# Altium schematic sheet Power.SchDoc(Power) (continued)
## Library data of the parts (continued)
FB1 = FBMH3225HM601NT: Ferrite Beads Power Chip 600Ohm 30% 100MHz 3A 0.042Ohm DCR 1210 T/R; Manufacturer: TAIYO YUDEN; Manufacturer Package Identifier: 1210 (3225 Metric); DC Resistance (DCR): 42mΩ; Impedance: 600Ω; Number of Circuits: 1; Shielding: Unshielded; Value: 42mΩ; Case Code (Imperial): 1210; Case Code (Metric): 3225; Case/Package: 1210; Category: Ferrite Beads and Chips; Clock Frequency: 100MHz; Component Kind: Standard; ComponentLink1Description: https://datasheet.octopart.com:443/FBMH3225HM601NT-Taiyo-Yuden-datasheet-151884371.pdf?src-supplier=IHS; ComponentLink1URL: https://datasheet.octopart.com:443/FBMH3225HM601NT-Taiyo-Yuden-datasheet-151884371.pdf?src-supplier=IHS; ComponentLink2Description: https://datasheet.octopart.com:443/FBMH3225HM601NT-Taiyo-Yuden-datasheet-154808381.pdf?src-supplier=Master+Electronics; ComponentLink2URL: https://datasheet.octopart.com:443/FBMH3225HM601NT-Taiyo-Yuden-datasheet-154808381.pdf?src-supplier=Master+Electronics; ComponentLink3Description: https://datasheet.octopart.com:443/FBMH3225HM601NT-Taiyo-Yuden-datasheet-41181550.pdf?src-supplier=Newark; ComponentLink3URL: https://datasheet.octopart.com:443/FBMH3225HM601NT-Taiyo-Yuden-datasheet-41181550.pdf?src-supplier=Newark; ComponentLink4Description: https://datasheet.octopart.com:443/FBMH3225HM601NT-Taiyo-Yuden-datasheet-27088936.pdf?src-supplier=Newark; ComponentLink4URL: https://datasheet.octopart.com:443/FBMH3225HM601NT-Taiyo-Yuden-datasheet-27088936.pdf?src-supplier=Newark; ComponentLink5Description: https://datasheet.octopart.com:443/FBMH3225HM601NT-Taiyo-Yuden-datasheet-17272606.pdf?src-supplier=Newark; ComponentLink5URL: https://datasheet.octopart.com:443/FBMH3225HM601NT-Taiyo-Yuden-datasheet-17272606.pdf?src-supplier=Newark; ComponentLink6Description: https://datasheet.octopart.com:443/FBMH3225HM601NT-Taiyo-Yuden-datasheet-101598981.pdf?src-supplier=Newark; ComponentLink6URL: https://datasheet.octopart.com:443/FBMH3225HM601NT-Taiyo-Yuden-datasheet-101598981.pdf?src-supplier=Newark; ComponentLink7Description: https://datasheet.octopart.com:443/FBMH3225HM601NT-Taiyo-Yuden-datasheet-10545600.pdf?src-supplier=Factory+Futures; ComponentLink7URL: https://datasheet.octopart.com:443/FBMH3225HM601NT-Taiyo-Yuden-datasheet-10545600.pdf?src-supplier=Factory+Futures; ComponentLink8Description: https://datasheet.octopart.com:443/FBMH3225HM601NT-Taiyo-Yuden-datasheet-119523.pdf?src-supplier=Farnell; ComponentLink8URL: https://datasheet.octopart.com:443/FBMH3225HM601NT-Taiyo-Yuden-datasheet-119523.pdf?src-supplier=Farnell; ComponentLink9Description: https://datasheet.octopart.com:443/FBMH3225HM601NT-Taiyo-Yuden-datasheet-10742123.pdf?src-supplier=Farnell; ComponentLink9URL: https://datasheet.octopart.com:443/FBMH3225HM601NT-Taiyo-Yuden-datasheet-10742123.pdf?src-supplier=Farnell; ComponentLink10Description: https://datasheet.octopart.com:443/FBMH3225HM601NT-Taiyo-Yuden-datasheet-10622963.pdf?src-supplier=Farnell; ComponentLink10URL: https://datasheet.octopart.com:443/FBMH3225HM601NT-Taiyo-Yuden-datasheet-10622963.pdf?src-supplier=Farnell; ComponentLink11Description: https://datasheet.octopart.com:443/FBMH3225HM601NT-Taiyo-Yuden-datasheet-10618026.pdf?src-supplier=Farnell; ComponentLink11URL: https://datasheet.octopart.com:443/FBMH3225HM601NT-Taiyo-Yuden-datasheet-10618026.pdf?src-supplier=Farnell; ComponentLink12Description: https://datasheet.octopart.com:443/FBMH3225HM601NT-Taiyo-Yuden-datasheet-10549048.pdf?src-supplier=Farnell; ComponentLink12URL: https://datasheet.octopart.com:443/FBMH3225HM601NT-Taiyo-Yuden-datasheet-10549048.pdf?src-supplier=Farnell; ComponentLink13Description: https://datasheet.octopart.com:443/FBMH3225HM601NT-Taiyo-Yuden-datasheet-10671320.pdf?src-supplier=Farnell; ComponentLink13URL: https://datasheet.octopart.com:443/FBMH3225HM601NT-Taiyo-Yuden-datasheet-10671320.pdf?src-supplier=Farnell; ComponentLink14Description: https://datasheet.octopart.com:443/FBMH3225HM601NT-Taiyo-Yuden-datasheet-10620942.pdf?src-supplier=Farnell; ComponentLink14URL: https://datasheet.octopart.com:443/FBMH3225HM601NT-Taiyo-Yuden-datasheet-10620942.pdf?src-supplier=Farnell; ComponentLink15Description: https://datasheet.octopart.com:443/FBMH3225HM601NT-Taiyo-Yuden-datasheet-10408854.pdf?src-supplier=Farnell; ComponentLink15URL: https://datasheet.octopart.com:443/FBMH3225HM601NT-Taiyo-Yuden-datasheet-10408854.pdf?src-supplier=Farnell; ComponentLink16Description: https://datasheet.octopart.com:443/FBMH3225HM601NT-Taiyo-Yuden-datasheet-10283011.pdf?src-supplier=Farnell; ComponentLink16URL: https://datasheet.octopart.com:443/FBMH3225HM601NT-Taiyo-Yuden-datasheet-10283011.pdf?src-supplier=Farnell; ComponentLink17Description: https://datasheet.octopart.com:443/FBMH3225HM601NT-Taiyo-Yuden-datasheet-10031713.pdf?src-supplier=Farnell; ComponentLink17URL: https://datasheet.octopart.com:443/FBMH3225HM601NT-Taiyo-Yuden-datasheet-10031713.pdf?src-supplier=Farnell; ComponentLink18Description: https://datasheet.octopart.com:443/FBMH3225HM601NT-Taiyo-Yuden-datasheet-8857305.pdf?src-supplier=Farnell; ComponentLink18URL: https://datasheet.octopart.com:443/FBMH3225HM601NT-Taiyo-Yuden-datasheet-8857305.pdf?src-supplier=Farnell; ComponentLink19Description: https://datasheet.octopart.com:443/FBMH3225HM601NT-Taiyo-Yuden-datasheet-10854574.pdf?src-supplier=Farnell; ComponentLink19URL: https://datasheet.octopart.com:443/FBMH3225HM601NT-Taiyo-Yuden-datasheet-10854574.pdf?src-supplier=Farnell; ComponentLink20Description: https://datasheet.octopart.com:443/FBMH3225HM601NT-Taiyo-Yuden-datasheet-8820216.pdf?src-supplier=Farnell; ComponentLink20URL: https://datasheet.octopart.com:443/FBMH3225HM601NT-Taiyo-Yuden-datasheet-8820216.pdf?src-supplier=Farnell; ComponentLink21Description: https://datasheet.octopart.com:443/FBMH3225HM601NT-Taiyo-Yuden-datasheet-120265.pdf?src-supplier=Farnell; ComponentLink21URL: https://datasheet.octopart.com:443/FBMH3225HM601NT-Taiyo-Yuden-datasheet-120265.pdf?src-supplier=Farnell; ComponentLink22Description: https://datasheet.octopart.com:443/FBMH3225HM601NT-Taiyo-Yuden-datasheet-5326109.pdf?src-supplier=Farnell; ComponentLink22URL: https://datasheet.octopart.com:443/FBMH3225HM601NT-Taiyo-Yuden-datasheet-5326109.pdf?src-supplier=Farnell; ComponentLink23Description: https://datasheet.octopart.com:443/FBMH3225HM601NT-Taiyo-Yuden-datasheet-118905.pdf?src-supplier=Farnell; ComponentLink23URL: https://datasheet.octopart.com:443/FBMH3225HM601NT-Taiyo-Yuden-datasheet-118905.pdf?src-supplier=Farnell; ComponentLink24Description: https://datasheet.octopart.com:443/FBMH3225HM601NT-Taiyo-Yuden-datasheet-1645.pdf?src-supplier=Farnell; ComponentLink24URL: https://datasheet.octopart.com:443/FBMH3225HM601NT-Taiyo-Yuden-datasheet-1645.pdf?src-supplier=Farnell; ComponentLink25Description: https://datasheet.octopart.com:443/FBMH3225HM601NT-Taiyo-Yuden-datasheet-101669861.pdf?src-supplier=Future+Electronics; ComponentLink25URL: https://datasheet.octopart.com:443/FBMH3225HM601NT-Taiyo-Yuden-datasheet-101669861.pdf?src-supplier=Future+Electronics; ComponentLink26Description: https://datasheet.octopart.com:443/FBMH3225HM601NT-Taiyo-Yuden-datasheet-99833420.pdf?src-supplier=Future+Electronics; ComponentLink26URL: https://datasheet.octopart.com:443/FBMH3225HM601NT-Taiyo-Yuden-datasheet-99833420.pdf?src-supplier=Future+Electronics; ComponentLink27Description: https://datasheet.octopart.com:443/FBMH3225HM601NT-Taiyo-Yuden-datasheet-62109277.pdf?src-supplier=Electro+Sonic; ComponentLink27URL: https://datasheet.octopart.com:443/FBMH3225HM601NT-Taiyo-Yuden-datasheet-62109277.pdf?src-supplier=Electro+Sonic; ComponentLink28Description: https://datasheet.octopart.com:443/FBMH3225HM601NT-Taiyo-Yuden-datasheet-6398493.pdf?src-supplier=element14; ComponentLink28URL: https://datasheet.octopart.com:443/FBMH3225HM601NT-Taiyo-Yuden-datasheet-6398493.pdf?src-supplier=element14; Core Material: Ferrite; Current Rating: 3A; Depth: 2.8mm; ESR: 42mΩ; Export Control Classification Number (ECCN) Code: EAR99; Frequency: 100MHz; Halogen Free: Halogen Free; Harmonized Tariff Schedule (HTS) Code: 8504.50.80.00; Height: 2.5mm; Introduction Date: 2002-01-01; Lead Free: Lead Free; Length: 3.2mm; Lifecycle Status: Production; LTB Date: 2026-03-31; LTD Date: 2026-03-31; Manufacturer Part Number: FBMH3225HM601NT; Material: Ferrite; Max Current Rating: 3A; Max DC Current: 3A; Max Length: 3.5mm; Max Operating Temperature: 85°C; Max Thickness: 2.8mm; Max Width: 2.8mm; Min Length: 2.9mm; Min Operating Temperature: -40°C; Min Thickness: 2.2mm; Min Width: 2.2mm; Mounting Technology: Surface Mount; Number of Lines: 1; Number of Terminals: 2; Packaging: Digi-Reel®; Pins: 2; Pricing: 1=0.53, 10=0.371, 25=0.3204, 50=0.2856, 100=0.2537, 250=0.21508, 500=0.18832 (USD); REACH SVHC: Unaffected; RMS Current (Irms): 3A; RoHS: Compliant; Stock: 198378; Supplier: DigiKey; Supplier Part Number: 587-1755-1-ND; Termination: SMD/SMT; Test Frequency: 100MHz; Tolerance: 30%; Width: 2.5mm; Library Name: Tremelo_Pedal.SchLib
J1 = 54-00129: Conn DC Power RCP 2 POS Solder RA Thru-Hole 3 Terminal 1 Port; Manufacturer: Tensility; Current Rating: 6A; Voltage Rating: 48V; Category: Connectors; Component Kind: Standard; ComponentLink1Description: https://datasheet.octopart.com:443/54-00129-Tensility-datasheet-189118334.pdf?src-supplier=Master+Electronics; ComponentLink1URL: https://datasheet.octopart.com:443/54-00129-Tensility-datasheet-189118334.pdf?src-supplier=Master+Electronics; Contact Material: Brass; Export Control Classification Number (ECCN) Code: EAR99; Harmonized Tariff Schedule (HTS) Code: 8536.69.40.20; Manufacturer Part Number: 54-00129; Max Operating Temperature: 85°C; Min Operating Temperature: -25°C; Pricing: 1=0.88, 10=0.745, 25=0.6976, 50=0.6644, 100=0.6326, 250=0.59296, 500=0.56462, 1000=0.53765, 2500=0.50399 (USD); REACH SVHC: Affected; RoHS: Compliant; Schedule B: 8536695050, 8536695050|8536695050|8536695050|8536695050|8536695050; Stock: 18126; Supplier: DigiKey; Supplier Part Number: 839-54-00129-ND; Termination: Solder; Library Name: Tremelo_Pedal.SchLib
Q1 = MMBT2222ALT1G: Bipolar (BJT) Transistor NPN 40V 600mA 300MHz 225mW Surface Mount SOT-23-3 Tape & Reel; Manufacturer: onsemi; Manufacturer Lifecycle Status: ACTIVE; Collector Base Voltage (VCBO): 75V; Collector Emitter Saturation Voltage: 300mV; Element Configuration: Single; Emitter Base Voltage (VEBO): 6V; hFE Min: 75; Max Junction Temperature (Tj): 150°C; Max Power Dissipation: 300mW; Number of Elements: 1; Type: General Purpose; Case/Package: SOT-23; Category: BJTs; China RoHS: Compliant; Clock Frequency: 300MHz; Collector Emitter Breakdown Voltage: 40V; Collector Emitter Voltage (VCEO): 40V; Component Kind: Standard; ComponentLink1Description: https://datasheet.octopart.com:443/MMBT2222ALT1G-ON-Semiconductor-datasheet-610557.pdf?src-supplier=onsemi; ComponentLink1URL: https://datasheet.octopart.com:443/MMBT2222ALT1G-ON-Semiconductor-datasheet-610557.pdf?src-supplier=onsemi; ComponentLink2Description: https://datasheet.octopart.com:443/MMBT2222ALT1G-ON-Semiconductor-datasheet-8326918.pdf?src-supplier=onsemi; ComponentLink2URL: https://datasheet.octopart.com:443/MMBT2222ALT1G-ON-Semiconductor-datasheet-8326918.pdf?src-supplier=onsemi; ComponentLink3Description: https://datasheet.octopart.com:443/MMBT2222ALT1G-onsemi-datasheet-180963556.pdf?src-supplier=IHS; ComponentLink3URL: https://datasheet.octopart.com:443/MMBT2222ALT1G-onsemi-datasheet-180963556.pdf?src-supplier=IHS; ComponentLink4Description: https://datasheet.octopart.com:443/MMBT2222ALT1G-ON-Semiconductor-datasheet-93401943.pdf?src-supplier=Upverter; ComponentLink4URL: https://datasheet.octopart.com:443/MMBT2222ALT1G-ON-Semiconductor-datasheet-93401943.pdf?src-supplier=Upverter; ComponentLink5Description: https://sigma.octopart.com:443/138897241/technical_drawing/ON-Semiconductor-MMBT2222ALT1G.pdf?src-supplier=Upverter; ComponentLink5URL: https://sigma.octopart.com:443/138897241/technical_drawing/ON-Semiconductor-MMBT2222ALT1G.pdf?src-supplier=Upverter; ComponentLink6Description: https://datasheet.octopart.com:443/MMBT2222ALT1G-ON-Semiconductor-datasheet-11598741.pdf?src-supplier=Farnell; ComponentLink6URL: https://datasheet.octopart.com:443/MMBT2222ALT1G-ON-Semiconductor-datasheet-11598741.pdf?src-supplier=Farnell; ComponentLink7Description: https://datasheet.octopart.com:443/MMBT2222ALT1G-ON-Semiconductor-datasheet-155100582.pdf?src-supplier=TME; ComponentLink7URL: https://datasheet.octopart.com:443/MMBT2222ALT1G-ON-Semiconductor-datasheet-155100582.pdf?src-supplier=TME; ComponentLink8Description: https://datasheet.octopart.com:443/MMBT2222ALT1G-ON-Semiconductor-datasheet-9666439.pdf?src-supplier=RS+(Formerly+Allied+Electronics); ComponentLink8URL: https://datasheet.octopart.com:443/MMBT2222ALT1G-ON-Semiconductor-datasheet-9666439.pdf?src-supplier=RS+(Formerly+Allied+Electronics); ComponentLink9Description: http://sigma.octopart.com:80/150618391/rohs_statement/ON-Semiconductor-MMBT2222ALT1G.pdf?src-supplier=Compliance+Statements; ComponentLink9URL: http://sigma.octopart.com:80/150618391/rohs_statement/ON-Semiconductor-MMBT2222ALT1G.pdf?src-supplier=Compliance+Statements; ComponentLink10Description: http://sigma.octopart.com:80/reach_statement/ON-Semiconductor-company-79.pdf?src-supplier=Compliance+Statements; ComponentLink10URL: http://sigma.octopart.com:80/reach_statement/ON-Semiconductor-company-79.pdf?src-supplier=Compliance+Statements; ComponentLink11Description: http://sigma.octopart.com:80/rohs_statement/Fairchild-Semiconductor-company-11.pdf?src-supplier=Compliance+Statements; ComponentLink11URL: http://sigma.octopart.com:80/rohs_statement/Fairchild-Semiconductor-company-11.pdf?src-supplier=Compliance+Statements; ComponentLink12Description: http://sigma.octopart.com:80/rohs_statement/Fairchild-Semiconductor-company-10.pdf?src-supplier=Compliance+Statements; ComponentLink12URL: http://sigma.octopart.com:80/rohs_statement/Fairchild-Semiconductor-company-10.pdf?src-supplier=Compliance+Statements; ComponentLink13Description: http://sigma.octopart.com:80/reach_statement/Fairchild-Semiconductor-company-9.pdf?src-supplier=Compliance+Statements; ComponentLink13URL: http://sigma.octopart.com:80/reach_statement/Fairchild-Semiconductor-company-9.pdf?src-supplier=Compliance+Statements; ComponentLink14Description: https://octopart.com:443/opatz8j6/c1?t=qUfD7E2yMa3Yk1vLXuv8OyRrgB35oCXT9-4lZ8hWbx63qVKY6CZ5Wx2VuO9N1oPnQ-OFoqvKrlbsAQTZvNQspaV8PzM0KMZrM5qimrAS2Zvqv1Jmjhq1XNHbtRnsNKP4QJNWwAWuXHzspahX0GPiJWYED5gCsLAWVM_Us9JHtNxAPP0IoypvAlSchXMw0lNpAwxWQgbKGZRbXI3egbgLrDMNwqXcjYqK5Lts70q_IXAZE63Sk2NOAQAaUe72vfA1BkFyWuN3Pafh36sNqvi0mkmDOT1QicIxslPPZmZplA9bng580C9Wj3PNCWJxQeY76huV7G-1Ts4qzJ3fZbIoJFL71Wq9dv0nuozXNA_jig8&src-supplier=Compliance+Statements; ComponentLink14URL: https://octopart.com:443/opatz8j6/c1?t=qUfD7E2yMa3Yk1vLXuv8OyRrgB35oCXT9-4lZ8hWbx63qVKY6CZ5Wx2VuO9N1oPnQ-OFoqvKrlbsAQTZvNQspaV8PzM0KMZrM5qimrAS2Zvqv1Jmjhq1XNHbtRnsNKP4QJNWwAWuXHzspahX0GPiJWYED5gCsLAWVM_Us9JHtNxAPP0IoypvAlSchXMw0lNpAwxWQgbKGZRbXI3egbgLrDMNwqXcjYqK5Lts70q_IXAZE63Sk2NOAQAaUe72vfA1BkFyWuN3Pafh36sNqvi0mkmDOT1QicIxslPPZmZplA9bng580C9Wj3PNCWJxQeY76huV7G-1Ts4qzJ3fZbIoJFL71Wq9dv0nuozXNA_jig8&src-supplier=Compliance+Statements; ComponentLink15Description: https://octopart.com:443/opatz8j6/c1?t=kIt2DkC0MAyf1TCB62HN4xrKGgEtOl4LXqimdH22cZ_WyAC3wC3E4Hdpe_0KznFcpnPTUsRM23Gl7aUqdnmdck66MDx_v__wJydKmihBqdbws59vCRNJfil0wkQBEwEF0_4QxDPd5IBZVfNlTKO6LnTTXAfkmbX-sPSl7uFpYsmiM-Sa7nH5TCfNiTPGpSB6kRuHhRRQlxAWjs174o_s8ub9WNbbjmqKG85Y2S3lbzJ_5XqEIWEtZ9AAJuebf_DLORk6e_Bzf-XCemQihTbyzJJFMLlrj4SBSEfiYkGJOKdEc8sNzlylH9ez8k9mXm3ufCPCTItPmmbs2xTNOrcgSFHxJ3mw6Y0m7fPrd-6i5Jlh&src-supplier=Compliance+Statements; ComponentLink15URL: https://octopart.com:443/opatz8j6/c1?t=kIt2DkC0MAyf1TCB62HN4xrKGgEtOl4LXqimdH22cZ_WyAC3wC3E4Hdpe_0KznFcpnPTUsRM23Gl7aUqdnmdck66MDx_v__wJydKmihBqdbws59vCRNJfil0wkQBEwEF0_4QxDPd5IBZVfNlTKO6LnTTXAfkmbX-sPSl7uFpYsmiM-Sa7nH5TCfNiTPGpSB6kRuHhRRQlxAWjs174o_s8ub9WNbbjmqKG85Y2S3lbzJ_5XqEIWEtZ9AAJuebf_DLORk6e_Bzf-XCemQihTbyzJJFMLlrj4SBSEfiYkGJOKdEc8sNzlylH9ez8k9mXm3ufCPCTItPmmbs2xTNOrcgSFHxJ3mw6Y0m7fPrd-6i5Jlh&src-supplier=Compliance+Statements; ComponentLink16Description: https://octopart.com:443/opatz8j6/c1?t=RVBw5Uc_Pazp42tUvaXS935cT8-DxpHWeVIh4jXHYy4FesJzPPCIcMiqYsNP7NqI3m7N8TKf8Albb342jbgVNXmkWnUz6gHgxAhyOhAnnoxGWZ5PI8dlUF9cAioFHqWVOV_D_N8fBmxKyk3z8i0FyerI2MquCKm8Ln-q5fn8BS6QCo5pr23rcU5tsu1QR4Af6HD8BmMSt_8GMuyCwA2NkrD9fIVaHy3VtBAKDxaMVO6y7u7LpPWHctH9hE20XcPiOAaUdi-rW2B7fXh7GTWiQLP3gRv8gfdHIShjsrlWD-oME1xcy5ic1bClPT5U4eHZ9MSIKaRqPzisKfm__r5FQX-RXRc0UcKzTW2wqRtUdpg&src-supplier=Compliance+Statements; ComponentLink16URL: https://octopart.com:443/opatz8j6/c1?t=RVBw5Uc_Pazp42tUvaXS935cT8-DxpHWeVIh4jXHYy4FesJzPPCIcMiqYsNP7NqI3m7N8TKf8Albb342jbgVNXmkWnUz6gHgxAhyOhAnnoxGWZ5PI8dlUF9cAioFHqWVOV_D_N8fBmxKyk3z8i0FyerI2MquCKm8Ln-q5fn8BS6QCo5pr23rcU5tsu1QR4Af6HD8BmMSt_8GMuyCwA2NkrD9fIVaHy3VtBAKDxaMVO6y7u7LpPWHctH9hE20XcPiOAaUdi-rW2B7fXh7GTWiQLP3gRv8gfdHIShjsrlWD-oME1xcy5ic1bClPT5U4eHZ9MSIKaRqPzisKfm__r5FQX-RXRc0UcKzTW2wqRtUdpg&src-supplier=Compliance+Statements; Contact Plating: Tin; Country of Origin: Mainland China; Current Rating: 600mA; Export Control Classification Number (ECCN) Code: EAR99; Frequency: 300MHz; Gain Bandwidth Product: 300MHz; Height: 1.11mm; Introduction Date: 1999-01-01; Lead Free: Lead Free; Length: 2.9mm; Lifecycle Status: Production; Manufacturer Part Number: MMBT2222ALT1G; Max Breakdown Voltage: 40V; Max Collector Current: 600mA; Max Operating Temperature: 150°C; Min Operating Temperature: -55°C; Number of Terminals: 3; Packaging: Tape and Reel; Pins: 3; Polarity: NPN; Power Dissipation: 225mW; Pricing: 1=0.15, 10=0.091, 100=0.0559, 500=0.04042, 1000=0.03538 (USD); Radiation Hardening: No; REACH SVHC: Unaffected; RoHS: Compliant; Schedule B: 8541210080, 8541210080|8541210080|8541210080|8541210080|8541210080; Stock: 2015515; Supplier: DigiKey; Supplier Part Number: MMBT2222ALT1GOSDKR-ND; Transition Frequency: 300MHz; Voltage Rating (DC): 40V; Width: 1.3mm; Library Name: Tremelo_Pedal.SchLib
R1 = ERJ-P14J511U: Res Thick Film 1210 510 Ohm 5% 0.5W ±200ppm/°C Molded SMD Embossed Carrier T/R; Manufacturer: Panasonic; Manufacturer Lifecycle Status: ACTIVE; Part Series: Anti-Surge High Power Thick Film Chip Resistors; Max Power Dissipation: 500mW; Value: 510Ω; Voltage Rating: 200V; Case Code (Imperial): 1210; Case/Package: 1210; Category: Chip SMD Resistors; Component Kind: Standard; ComponentLink1Description: https://datasheet.octopart.com:443/ERJ-P14J511U-Panasonic-datasheet-68299097.pdf?src-supplier=Panasonic; ComponentLink1URL: https://datasheet.octopart.com:443/ERJ-P14J511U-Panasonic-datasheet-68299097.pdf?src-supplier=Panasonic; ComponentLink2Description: https://datasheet.octopart.com:443/ERJ-P14J511U-Panasonic-datasheet-142522929.pdf?src-supplier=Master+Electronics; ComponentLink2URL: https://datasheet.octopart.com:443/ERJ-P14J511U-Panasonic-datasheet-142522929.pdf?src-supplier=Master+Electronics; ComponentLink3Description: https://datasheet.octopart.com:443/ERJ-P14J511U-Panasonic-datasheet-10403718.pdf?src-supplier=RS+(Formerly+Allied+Electronics); ComponentLink3URL: https://datasheet.octopart.com:443/ERJ-P14J511U-Panasonic-datasheet-10403718.pdf?src-supplier=RS+(Formerly+Allied+Electronics); ComponentLink4Description: https://datasheet.octopart.com:443/ERJ-P14J511U-Panasonic-datasheet-62107015.pdf?src-supplier=Electro+Sonic; ComponentLink4URL: https://datasheet.octopart.com:443/ERJ-P14J511U-Panasonic-datasheet-62107015.pdf?src-supplier=Electro+Sonic; ComponentLink5Description: https://datasheet.octopart.com:443/ERJ-P14J511U-Panasonic-datasheet-16484091.pdf?src-supplier=Electro+Sonic; ComponentLink5URL: https://datasheet.octopart.com:443/ERJ-P14J511U-Panasonic-datasheet-16484091.pdf?src-supplier=Electro+Sonic; ComponentLink6Description: https://datasheet.octopart.com:443/ERJ-P14J511U-Panasonic-datasheet-13272697.pdf?src-supplier=Electro+Sonic; ComponentLink6URL: https://datasheet.octopart.com:443/ERJ-P14J511U-Panasonic-datasheet-13272697.pdf?src-supplier=Electro+Sonic; ComponentLink7Description: https://datasheet.octopart.com:443/ERJ-P14J511U-Panasonic-datasheet-38423199.pdf?src-supplier=Electro+Sonic; ComponentLink7URL: https://datasheet.octopart.com:443/ERJ-P14J511U-Panasonic-datasheet-38423199.pdf?src-supplier=Electro+Sonic; ComponentLink8Description: https://datasheet.octopart.com:443/ERJ-P14J511U-Panasonic-datasheet-118681.pdf?src-supplier=DigiKey; ComponentLink8URL: https://datasheet.octopart.com:443/ERJ-P14J511U-Panasonic-datasheet-118681.pdf?src-supplier=DigiKey; Composition: Thick Film; Contact Plating: Tin; Depth: 2.5mm; Features: Automotive AEC-Q200; Height: 600µm; Lead Free: Lead Free; Length: 3.2mm; Lifecycle Status: Production; Manufacturer Part Number: ERJ-P14J511U; Max Operating Temperature: 155°C; Military Standard: Not; Min Operating Temperature: -55°C; Mounting Technology: Surface Mount; Number of Terminals: 2; Number of Terminations: 2; Packaging: Cut Tape; Power Rating: 500mW; Pricing: 1=0.23, 10=0.12, 25=0.0952, 50=0.0814, 100=0.0706, 250=0.05956, 500=0.05326, 1000=0.04824 (USD); Radiation Hardening: No; Ratings: AEC-Q200; REACH SVHC: Unaffected; RoHS: Compliant; Schedule B: 8533210045, 8533210045|8533210045|8533210045|8533210045|8533210045; Stock: 5267; Supplier: DigiKey; Supplier Part Number: P510ASDKR-ND; Temperature Coefficient: 200ppm/°C; Tolerance: 5%; Voltage Rating (DC): 200V; Width: 2.5mm; Working Voltage: 200V; Library Name: Tremelo_Pedal.SchLib
R28 = CR0603-FX-2610ELF: Fixed Resistor, Metal Glaze/thick Film, 0.1W, 261ohm, 75V, 1% +/-Tol, 100ppm/Cel, Surface Mount, 0603; Manufacturer: Bourns; Max Power Dissipation: 100mW; Temperature Coefficient: 100ppm/°C; Value: 261Ω; Case Code (Imperial): 0603; Case Code (Metric): 1608; Case/Package: 0603; Category: Chip SMD Resistors; China RoHS: Non-Compliant; Component Kind: Standard; ComponentLink1Description: https://datasheet.octopart.com:443/CR0603-FX-2610ELF-Bourns-datasheet-159578630.pdf?src-supplier=IHS; ComponentLink1URL: https://datasheet.octopart.com:443/CR0603-FX-2610ELF-Bourns-datasheet-159578630.pdf?src-supplier=IHS; ComponentLink2Description: https://datasheet.octopart.com:443/CR0603-FX-2610ELF-Bourns-datasheet-181955239.pdf?src-supplier=IHS; ComponentLink2URL: https://datasheet.octopart.com:443/CR0603-FX-2610ELF-Bourns-datasheet-181955239.pdf?src-supplier=IHS; ComponentLink3Description: https://datasheet.octopart.com/CR0603-FX-2610ELF-Bourns-datasheet-5318152.pdf; ComponentLink3URL: https://datasheet.octopart.com/CR0603-FX-2610ELF-Bourns-datasheet-5318152.pdf; ComponentLink4Description: https://datasheet.octopart.com:443/CR0603-FX-2610ELF-Bourns-datasheet-154729663.pdf?src-supplier=Electro+Sonic; ComponentLink4URL: https://datasheet.octopart.com:443/CR0603-FX-2610ELF-Bourns-datasheet-154729663.pdf?src-supplier=Electro+Sonic; ComponentLink5Description: http://sigma.octopart.com:80/conflict_mineral_statement/Bourns-company-36.pdf?src-supplier=Compliance+Statements; ComponentLink5URL: http://sigma.octopart.com:80/conflict_mineral_statement/Bourns-company-36.pdf?src-supplier=Compliance+Statements; Composition: Thick Film; Contact Plating: Tin; Country of Origin: Taiwan; Depth: 800µm; Export Control Classification Number (ECCN) Code: EAR99; Features: Moisture Resistant; Harmonized Tariff Schedule (HTS) Code: 8533.21.00.30; Height: 450µm; Introduction Date: 2003-06-20; Length: 1.6mm; Lifecycle Status: Production; Manufacturer Part Number: CR0603-FX-2610ELF; Max Operating Temperature: 155°C; Military Standard: Not; Min Operating Temperature: -55°C; Mounting Technology: Surface Mount; Number of Terminals: 2; Number of Terminations: 2; Packaging: Tape & Reel (TR); Power Rating: 100mW; Pricing: 1=0.1, 10=0.027, 25=0.02, 50=0.016, 100=0.013, 250=0.01004, 500=0.0084, 1000=0.00712 (USD); Radiation Hardening: No; REACH SVHC: Yes; RoHS: Compliant; Stock: 13733; Supplier: DigiKey; Supplier Part Number: 118-CR0603-FX-2610ELFCT-ND; Termination: SMD/SMT; Tolerance: 1%; Voltage Rating: 75V; Width: 800µm; Working Voltage: 75V; Library Name: EcoCar_Accessory_Controller_V2.IntLib
R29 = CRCW0603649RFKEAHP: Res Thick Film 0603 649 Ohm 1% 0.33W(1/3W) ±100ppm/C Pad SMD Automotive T/R; Manufacturer: Vishay; Max Power Dissipation: 250mW; Power Rating: 330mW; Temperature Coefficient: 100ppm/°C; Value: 649Ω; Voltage Rating: 75V; Case Code (Imperial): 0603; Case Code (Metric): 1608; Case/Package: 0603; Category: Chip SMD Resistors; China RoHS: Non-Compliant; Component Kind: Standard; ComponentLink1Description: https://datasheet.octopart.com:443/CRCW0603649RFKEAHP-Vishay-datasheet-13148866.pdf?src-supplier=Future+Electronics; ComponentLink1URL: https://datasheet.octopart.com:443/CRCW0603649RFKEAHP-Vishay-datasheet-13148866.pdf?src-supplier=Future+Electronics; ComponentLink2Description: https://datasheet.octopart.com:443/CRCW0603649RFKEAHP-Vishay-datasheet-13131392.pdf?src-supplier=Future+Electronics; ComponentLink2URL: https://datasheet.octopart.com:443/CRCW0603649RFKEAHP-Vishay-datasheet-13131392.pdf?src-supplier=Future+Electronics; ComponentLink3Description: https://datasheet.octopart.com:443/CRCW0603649RFKEAHP-Vishay-datasheet-12593106.pdf?src-supplier=Future+Electronics; ComponentLink3URL: https://datasheet.octopart.com:443/CRCW0603649RFKEAHP-Vishay-datasheet-12593106.pdf?src-supplier=Future+Electronics; ComponentLink4Description: https://datasheet.octopart.com:443/CRCW0603649RFKEAHP-Vishay-datasheet-12544674.pdf?src-supplier=Future+Electronics; ComponentLink4URL: https://datasheet.octopart.com:443/CRCW0603649RFKEAHP-Vishay-datasheet-12544674.pdf?src-supplier=Future+Electronics; ComponentLink5Description: https://datasheet.octopart.com:443/CRCW0603649RFKEAHP-Vishay-datasheet-12531795.pdf?src-supplier=Future+Electronics; ComponentLink5URL: https://datasheet.octopart.com:443/CRCW0603649RFKEAHP-Vishay-datasheet-12531795.pdf?src-supplier=Future+Electronics; ComponentLink6Description: https://datasheet.octopart.com:443/CRCW0603649RFKEAHP-Vishay-datasheet-12518686.pdf?src-supplier=Future+Electronics; ComponentLink6URL: https://datasheet.octopart.com:443/CRCW0603649RFKEAHP-Vishay-datasheet-12518686.pdf?src-supplier=Future+Electronics; ComponentLink7Description: https://datasheet.octopart.com:443/CRCW0603649RFKEAHP-Vishay-datasheet-12509906.pdf?src-supplier=Future+Electronics; ComponentLink7URL: https://datasheet.octopart.com:443/CRCW0603649RFKEAHP-Vishay-datasheet-12509906.pdf?src-supplier=Future+Electronics; ComponentLink8Description: https://datasheet.octopart.com:443/CRCW0603649RFKEAHP-Vishay-datasheet-10669844.pdf?src-supplier=Future+Electronics; ComponentLink8URL: https://datasheet.octopart.com:443/CRCW0603649RFKEAHP-Vishay-datasheet-10669844.pdf?src-supplier=Future+Electronics; ComponentLink9Description: https://datasheet.octopart.com:443/CRCW0603649RFKEAHP-Vishay-datasheet-8703221.pdf?src-supplier=Future+Electronics; ComponentLink9URL: https://datasheet.octopart.com:443/CRCW0603649RFKEAHP-Vishay-datasheet-8703221.pdf?src-supplier=Future+Electronics; ComponentLink10Description: https://datasheet.octopart.com:443/CRCW0603649RFKEAHP-Vishay-datasheet-62316122.pdf?src-supplier=Future+Electronics; ComponentLink10URL: https://datasheet.octopart.com:443/CRCW0603649RFKEAHP-Vishay-datasheet-62316122.pdf?src-supplier=Future+Electronics; ComponentLink11Description: https://datasheet.octopart.com/CRCW0603649RFKEAHP-Vishay-datasheet-83567917.pdf; ComponentLink11URL: https://datasheet.octopart.com/CRCW0603649RFKEAHP-Vishay-datasheet-83567917.pdf; ComponentLink12Description: http://sigma.octopart.com:80/rohs_statement/Vishay-company-15.pdf?src-supplier=Compliance+Statements; ComponentLink12URL: http://sigma.octopart.com:80/rohs_statement/Vishay-company-15.pdf?src-supplier=Compliance+Statements; Composition: Thick Film; Country of Origin: Israel; Export Control Classification Number (ECCN) Code: EAR99; Features: Automotive AEC-Q200; Harmonized Tariff Schedule (HTS) Code: 8533.21.00.30; Height: 450µm; Introduction Date: 2008-07-29; Lead Free: Lead Free; Length: 1.6mm; Lifecycle Status: Production; Manufacturer Part Number: CRCW0603649RFKEAHP; Max Operating Temperature: 155°C; Min Operating Temperature: -55°C; Mounting Technology: Surface Mount; Number of Terminals: 2; Number of Terminations: 2; Packaging: Tape & Reel (TR); Pricing: 1=0.15, 10=0.075, 25=0.0588, 50=0.0492, 100=0.0419, 250=0.03444, 500=0.03018, 1000=0.02681 (USD); Ratings: AEC-Q200; REACH SVHC: Yes; RoHS: Compliant; Schedule B: 8533210045, 8533210045|8533210045|8533210045|8533210045|8533210045; Stock: 37905; Supplier: DigiKey; Supplier Part Number: 541-649SCT-ND; Tolerance: 1%; Width: 850µm; Working Voltage: 75V; Library Name: EcoCar_Accessory_Controller_V2.IntLib
R30 R31 = RC0603FR-101K4L: Fixed Resistor, Metal Glaze/Thick Film, 0.1W, 1400ohm, 75V, 1% +/-Tol, 100ppm/Cel, Surface Mount, 0603; Component Kind: Standard; Library Name: Tremelo_Pedal.SchLib
U1 = LT1054CS8#PBF: Switched Capacitor Regulator, 40kHz Switching Freq-Max, BIPolar, PDSO8; Manufacturer: Analog Devices; Manufacturer Lifecycle Status: PRODUCTION; Frequency: 25kHz; Max Output current: 100mA; Max Output Voltage: 30V; Max Supply Voltage: 15V; Min Input Voltage: 3.5V; Min Output Voltage: 2.5V; Number of Channels: 1; Operating Supply Current: 2.5mA; Output Type: Adjustable; Output Voltage: -5V; Synchronous Rectifier: No; Topology: Charge Pump; Category: Voltage Regulators - Switching; Component Kind: Standard; ComponentLink1Description: https://datasheet.octopart.com:443/LT1054CS8%23PBF-Analog-Devices-datasheet-10689257.pdf?src-supplier=Upverter; ComponentLink1URL: https://datasheet.octopart.com:443/LT1054CS8%23PBF-Analog-Devices-datasheet-10689257.pdf?src-supplier=Upverter; ComponentLink2Description: https://octopart.com:443/opatz8j6/c1?t=A7ysFrgObCMEfAsNu6lg9NpyA8yGLPIUk-HjTrteV2w2stkVZgT6qxkwwMo5PXUAE_mAkfGGL_6NvkKa7ytz7532mF5AVOb-nYQpVvuHT_5-VsmXS8_fOurU-fti_7VYG0DMCzuHEUl2sLSzR5DKdzu_s3ssz22p_Hztv5LwwnfqdEydKvJafOmkGomWWtQH5WJi7hLVs--tifHl4MBvMngzUIJF545QaynYn92jxVApxAW6mM6DhnFE_BbrcBv0pyFrVE8D2AluKDy9LPiykLW55CjRofSvBa8MpA4GXu6dlOKqsri4wg&src-supplier=Analog+Devices; ComponentLink2URL: https://octopart.com:443/opatz8j6/c1?t=A7ysFrgObCMEfAsNu6lg9NpyA8yGLPIUk-HjTrteV2w2stkVZgT6qxkwwMo5PXUAE_mAkfGGL_6NvkKa7ytz7532mF5AVOb-nYQpVvuHT_5-VsmXS8_fOurU-fti_7VYG0DMCzuHEUl2sLSzR5DKdzu_s3ssz22p_Hztv5LwwnfqdEydKvJafOmkGomWWtQH5WJi7hLVs--tifHl4MBvMngzUIJF545QaynYn92jxVApxAW6mM6DhnFE_BbrcBv0pyFrVE8D2AluKDy9LPiykLW55CjRofSvBa8MpA4GXu6dlOKqsri4wg&src-supplier=Analog+Devices; ComponentLink3Description: https://datasheet.octopart.com:443/LT1054CS8%23PBF-Analog-Devices-datasheet-32866005.pdf?src-supplier=element14+APAC; ComponentLink3URL: https://datasheet.octopart.com:443/LT1054CS8%23PBF-Analog-Devices-datasheet-32866005.pdf?src-supplier=element14+APAC; ComponentLink4Description: https://datasheet.octopart.com:443/LT1054CS8%23PBF-Analog-Devices-datasheet-163665885.pdf?src-supplier=Burklin+Elektronik; ComponentLink4URL: https://datasheet.octopart.com:443/LT1054CS8%23PBF-Analog-Devices-datasheet-163665885.pdf?src-supplier=Burklin+Elektronik; ComponentLink5Description: https://datasheet.octopart.com:443/LT1054CS8%23PBF-Linear-Technology-datasheet-527879.pdf?src-supplier=Component+Distributors+Inc.; ComponentLink5URL: https://datasheet.octopart.com:443/LT1054CS8%23PBF-Linear-Technology-datasheet-527879.pdf?src-supplier=Component+Distributors+Inc.; ComponentLink6Description: https://sigma.octopart.com:443/57689574/technical_drawing/Linear-Technology-LT1054CS8%23PBF.pdf?src-supplier=Linear+Technology; ComponentLink6URL: https://sigma.octopart.com:443/57689574/technical_drawing/Linear-Technology-LT1054CS8%23PBF.pdf?src-supplier=Linear+Technology; ComponentLink7Description: https://datasheet.octopart.com:443/LT1054CS8%23PBF-Linear-Technology-datasheet-11549094.pdf?src-supplier=Arrow+Electronics; ComponentLink7URL: https://datasheet.octopart.com:443/LT1054CS8%23PBF-Linear-Technology-datasheet-11549094.pdf?src-supplier=Arrow+Electronics; ComponentLink8Description: https://datasheet.octopart.com:443/LT1054CS8%23PBF-Linear-Technology-datasheet-14166759.pdf?src-supplier=iiiC; ComponentLink8URL: https://datasheet.octopart.com:443/LT1054CS8%23PBF-Linear-Technology-datasheet-14166759.pdf?src-supplier=iiiC; ComponentLink9Description: https://datasheet.octopart.com:443/LT1054CS8%23PBF-Linear-Technology-datasheet-7267381.pdf?src-supplier=Jameco+(USA); ComponentLink9URL: https://datasheet.octopart.com:443/LT1054CS8%23PBF-Linear-Technology-datasheet-7267381.pdf?src-supplier=Jameco+(USA); ComponentLink10Description: http://sigma.octopart.com:80/conflict_mineral_statement/Analog-Devices-company-33.pdf?src-supplier=Compliance+Statements; ComponentLink10URL: http://sigma.octopart.com:80/conflict_mineral_statement/Analog-Devices-company-33.pdf?src-supplier=Compliance+Statements; ComponentLink11Description: https://octopart.com:443/opatz8j6/c1?t=m-Om37K__F4V46EDvZkBomlWUXBUh7AcqhjfDxbg7Ju-Vdeu2GCwj-J1FMuLNXi1lWSFNjVFsCHONk5vpmWovEaycwW9FOOUQKJOxOsTrtt6NYV6aZoHqP4hVjQwhDMaJ5Xozk3j010Bbj10wXIs3dCWs869trxm5Z0fwQrOOEvkhyRVrsBO3pfQ_8pR6YEyM5lSPrl2B4lQ13JGBGnfEM91GZH8rMWbBC6KaFXNjNs_YEgfc36oy7pUNdM6qwgvhwqSTJUiQwGq5XTfS3sNIo9LKcpsBDilE-fjOlcrlJ9KkM0liWr8Pg&src-supplier=Compliance+Statements; ComponentLink11URL: https://octopart.com:443/opatz8j6/c1?t=m-Om37K__F4V46EDvZkBomlWUXBUh7AcqhjfDxbg7Ju-Vdeu2GCwj-J1FMuLNXi1lWSFNjVFsCHONk5vpmWovEaycwW9FOOUQKJOxOsTrtt6NYV6aZoHqP4hVjQwhDMaJ5Xozk3j010Bbj10wXIs3dCWs869trxm5Z0fwQrOOEvkhyRVrsBO3pfQ_8pR6YEyM5lSPrl2B4lQ13JGBGnfEM91GZH8rMWbBC6KaFXNjNs_YEgfc36oy7pUNdM6qwgvhwqSTJUiQwGq5XTfS3sNIo9LKcpsBDilE-fjOlcrlJ9KkM0liWr8Pg&src-supplier=Compliance+Statements; Height: 1.498mm; Lead Free: Lead Free; Length: 5mm; Lifecycle Status: Production; Manufacturer Part Number: LT1054CS8#PBF; Max Operating Temperature: 100°C; Min Operating Temperature: 0°C; Min Supply Voltage: 3.5V; Number of Outputs: 1; Output Current: 100mA; Packaging: Cut Tape; Pins: 8; Pricing: 1=11.21, 10=8.768, 25=8.1584, 100=7.4883, 300=7.11457, 500=6.97642, 1000=6.81801 (USD); Radiation Hardening: No; REACH SVHC: Unaffected; RoHS: Compliant; Schedule B: 8542390000, 8542390000|8542390000, 8542390000|8542390000|8542390000, 8542390000|8542390000|8542390000|8542390000; Stock: 162; Supplier: DigiKey; Supplier Part Number: 505-LT1054CS8#PBF-ND; Width: 3.988mm; Library Name: Tremelo_Pedal.SchLib
U2 = L78M05CDT-TR: Linear Voltage Regulator, Fixed, Positive Output, 35 V, 5 V, 500 mA, 3 Pins, TO-252 (DPAK); Manufacturer: STMicroelectronics; Dropout Voltage: 2V; Max Junction Temperature (Tj): 150°C; Max Output current: 500mA; Max Output Voltage: 5V; Max Supply Voltage: 25V; Min Input Voltage: 7V; Number of Channels: 1; Power Supply Rejection Ratio (PSRR): 62dB; Quiescent Current: 6mA; Tolerance: 5%; Case/Package: TO-252; Category: Voltage Regulators - Linear; China RoHS: Non-Compliant; Component Kind: Standard; ComponentLink1Description: https://datasheet.octopart.com:443/L78M05CDT-TR-STMicroelectronics-datasheet-165583385.pdf?src-supplier=IHS; ComponentLink1URL: https://datasheet.octopart.com:443/L78M05CDT-TR-STMicroelectronics-datasheet-165583385.pdf?src-supplier=IHS; ComponentLink2Description: https://datasheet.octopart.com:443/L78M05CDT-TR-STMicroelectronics-datasheet-27379258.pdf?src-supplier=element14+APAC; ComponentLink2URL: https://datasheet.octopart.com:443/L78M05CDT-TR-STMicroelectronics-datasheet-27379258.pdf?src-supplier=element14+APAC; ComponentLink3Description: https://datasheet.octopart.com:443/L78M05CDT-TR-STMicroelectronics-datasheet-16532497.pdf?src-supplier=element14+APAC; ComponentLink3URL: https://datasheet.octopart.com:443/L78M05CDT-TR-STMicroelectronics-datasheet-16532497.pdf?src-supplier=element14+APAC; ComponentLink4Description: https://datasheet.octopart.com:443/L78M05CDT-TR-STMicroelectronics-datasheet-11553388.pdf?src-supplier=Factory+Futures; ComponentLink4URL: https://datasheet.octopart.com:443/L78M05CDT-TR-STMicroelectronics-datasheet-11553388.pdf?src-supplier=Factory+Futures; ComponentLink5Description: https://datasheet.octopart.com:443/L78M05CDT-TR-STMicroelectronics-datasheet-10836188.pdf?src-supplier=Factory+Futures; ComponentLink5URL: https://datasheet.octopart.com:443/L78M05CDT-TR-STMicroelectronics-datasheet-10836188.pdf?src-supplier=Factory+Futures; ComponentLink6Description: https://datasheet.octopart.com:443/L78M05CDT-TR-STMicroelectronics-datasheet-10408700.pdf?src-supplier=Factory+Futures; ComponentLink6URL: https://datasheet.octopart.com:443/L78M05CDT-TR-STMicroelectronics-datasheet-10408700.pdf?src-supplier=Factory+Futures; ComponentLink7Description: https://datasheet.octopart.com:443/L78M05CDT-TR-STMicroelectronics-datasheet-96974199.pdf?src-supplier=Augswan; ComponentLink7URL: https://datasheet.octopart.com:443/L78M05CDT-TR-STMicroelectronics-datasheet-96974199.pdf?src-supplier=Augswan; ComponentLink8Description: https://datasheet.octopart.com:443/L78M05CDT-TR-STMicroelectronics-datasheet-5396621.pdf?src-supplier=element14; ComponentLink8URL: https://datasheet.octopart.com:443/L78M05CDT-TR-STMicroelectronics-datasheet-5396621.pdf?src-supplier=element14; ComponentLink9Description: https://datasheet.octopart.com:443/L78M05CDT-TR-STMicroelectronics-datasheet-1938.pdf?src-supplier=DigiKey; ComponentLink9URL: https://datasheet.octopart.com:443/L78M05CDT-TR-STMicroelectronics-datasheet-1938.pdf?src-supplier=DigiKey; ComponentLink10Description: https://datasheet.octopart.com:443/L78M05CDT-TR-STMicroelectronics-datasheet-177520198.pdf?src-supplier=Burklin+Elektronik; ComponentLink10URL: https://datasheet.octopart.com:443/L78M05CDT-TR-STMicroelectronics-datasheet-177520198.pdf?src-supplier=Burklin+Elektronik; ComponentLink11Description: https://datasheet.octopart.com:443/L78M05CDT-TR-STMicroelectronics-datasheet-12514604.pdf?src-supplier=TME; ComponentLink11URL: https://datasheet.octopart.com:443/L78M05CDT-TR-STMicroelectronics-datasheet-12514604.pdf?src-supplier=TME; ComponentLink12Description: https://datasheet.octopart.com:443/L78M05CDT-TR-STMicroelectronics-datasheet-14169723.pdf?src-supplier=iiiC; ComponentLink12URL: https://datasheet.octopart.com:443/L78M05CDT-TR-STMicroelectronics-datasheet-14169723.pdf?src-supplier=iiiC; ComponentLink13Description: https://datasheet.octopart.com:443/L78M05CDT-TR-STMicroelectronics-datasheet-7538763.pdf?src-supplier=Jameco+(USA); ComponentLink13URL: https://datasheet.octopart.com:443/L78M05CDT-TR-STMicroelectronics-datasheet-7538763.pdf?src-supplier=Jameco+(USA); ComponentLink14Description: https://datasheet.octopart.com:443/L78M05CDT-TR-STMicroelectronics-datasheet-109551.pdf?src-supplier=Nu+Horizons; ComponentLink14URL: https://datasheet.octopart.com:443/L78M05CDT-TR-STMicroelectronics-datasheet-109551.pdf?src-supplier=Nu+Horizons; ComponentLink15Description: https://datasheet.octopart.com:443/L78M05CDT-TR-STMicroelectronics-datasheet-113893.pdf?src-supplier=Sierra+IC; ComponentLink15URL: https://datasheet.octopart.com:443/L78M05CDT-TR-STMicroelectronics-datasheet-113893.pdf?src-supplier=Sierra+IC; Contact Plating: Tin; Height: 2.4mm; Height - Seated (Max): 2.63mm; Lead Free: Lead Free; Length: 6.5mm; Lifecycle Status: Production; Manufacturer Part Number: L78M05CDT-TR; Max Operating Temperature: 150°C; Min Operating Temperature: -40°C; Min Output Voltage: 5V; Mounting Technology: Surface Mount; Nominal Output Voltage: 5V; Number of Circuits: 1; Number of Outputs: 1; Number of Regulators: 1; Number of Terminals: 2; Output Current: 500mA; Output Type: Fixed; Output Voltage: 5V; Packaging: Tape and Reel; Pins: 3; Polarity: Positive; Pricing: 1=0.4, 10=0.28, 25=0.2496, 100=0.2159, 250=0.19976, 500=0.19004, 1000=0.18202 (USD); Radiation Hardening: No; REACH SVHC: No; RoHS: Compliant; Schedule B: 8542390000, 8542390000|8542390000, 8542390000|8542390000|8542390000, 8542390000|8542390000|8542390000|8542390000; Stock: 54052; Supplier: DigiKey; Supplier Part Number: 497-1203-6-ND; Terminal Pitch: 2.286mm; Voltage Tolerance: 5%; Weight: 4.535924g; Width: 6.1mm; Library Name: Tremelo_Pedal.SchLib
U3 = AP7361C-33ER-13: Ldo, Fixed, 3.3V, 1A, -40 To 125Deg C Rohs Compliant: Yes |Diodes Inc. AP7361C-33ER-13; Dropout Voltage: 360mV; Max Junction Temperature (Tj): 150°C; Max Supply Voltage: 6V; Min Current Limit: 1.1A; Min Input Voltage: 4.3V; Number of Channels: 1; Voltage Tolerance: 1%; Case/Package: SOP; Category: LDO Voltage Regulators; China RoHS: Compliant; Component Kind: Standard; ComponentLink1Description: https://datasheet.octopart.com:443/AP7361C-33ER-13-Diodes-Inc.-datasheet-159616639.pdf?src-supplier=element14+APAC; ComponentLink1URL: https://datasheet.octopart.com:443/AP7361C-33ER-13-Diodes-Inc.-datasheet-159616639.pdf?src-supplier=element14+APAC; ComponentLink2Description: https://datasheet.octopart.com:443/AP7361C-33ER-13-Diodes-Inc.-datasheet-101745716.pdf?src-supplier=HQonline; ComponentLink2URL: https://datasheet.octopart.com:443/AP7361C-33ER-13-Diodes-Inc.-datasheet-101745716.pdf?src-supplier=HQonline; ComponentLink3Description: https://datasheet.octopart.com:443/AP7361C-33ER-13-Diodes-Inc.-datasheet-158996477.pdf?src-supplier=SHENGYU+ELECTRONICS; ComponentLink3URL: https://datasheet.octopart.com:443/AP7361C-33ER-13-Diodes-Inc.-datasheet-158996477.pdf?src-supplier=SHENGYU+ELECTRONICS; Country of Origin: Mainland China; Export Control Classification Number (ECCN) Code: EAR99; Harmonized Tariff Schedule (HTS) Code: 8542.39.00.60; Height - Seated (Max): 1.8mm; Introduction Date: 2015-10-13; Length: 6.5mm; Lifecycle Status: Production; Manufacturer: Diodes Inc.; Manufacturer Part Number: AP7361C-33ER-13; Max Operating Temperature: 85°C; Min Operating Temperature: -40°C; Mounting Technology: Surface Mount; Number of Circuits: 1; Number of Outputs: 1; Number of Regulators: 1; Number of Terminals: 3; Output Current: 1A; Output Voltage: 3.3V; Pricing: 1=0.45, 10=0.317, 25=0.2832, 100=0.2456, 250=0.22768, 500=0.21686, 1000=0.20795 (USD); REACH SVHC: Yes; RoHS: Compliant; Stock: 2638; Supplier: DigiKey; Supplier Part Number: AP7361C-33ER-13DICT-ND; Terminal Pitch: 2.3mm; Width: 3.5mm; Library Name: EcoCar_Accessory_Controller_V2.IntLib
